# Altium schematic sheet S7CAS-PLEIADES-CARTE-MERE_P07_FPGA_2.SchDoc(S7CAS-PLEIADES-CARTE-MERE_P07_FPGA_2)
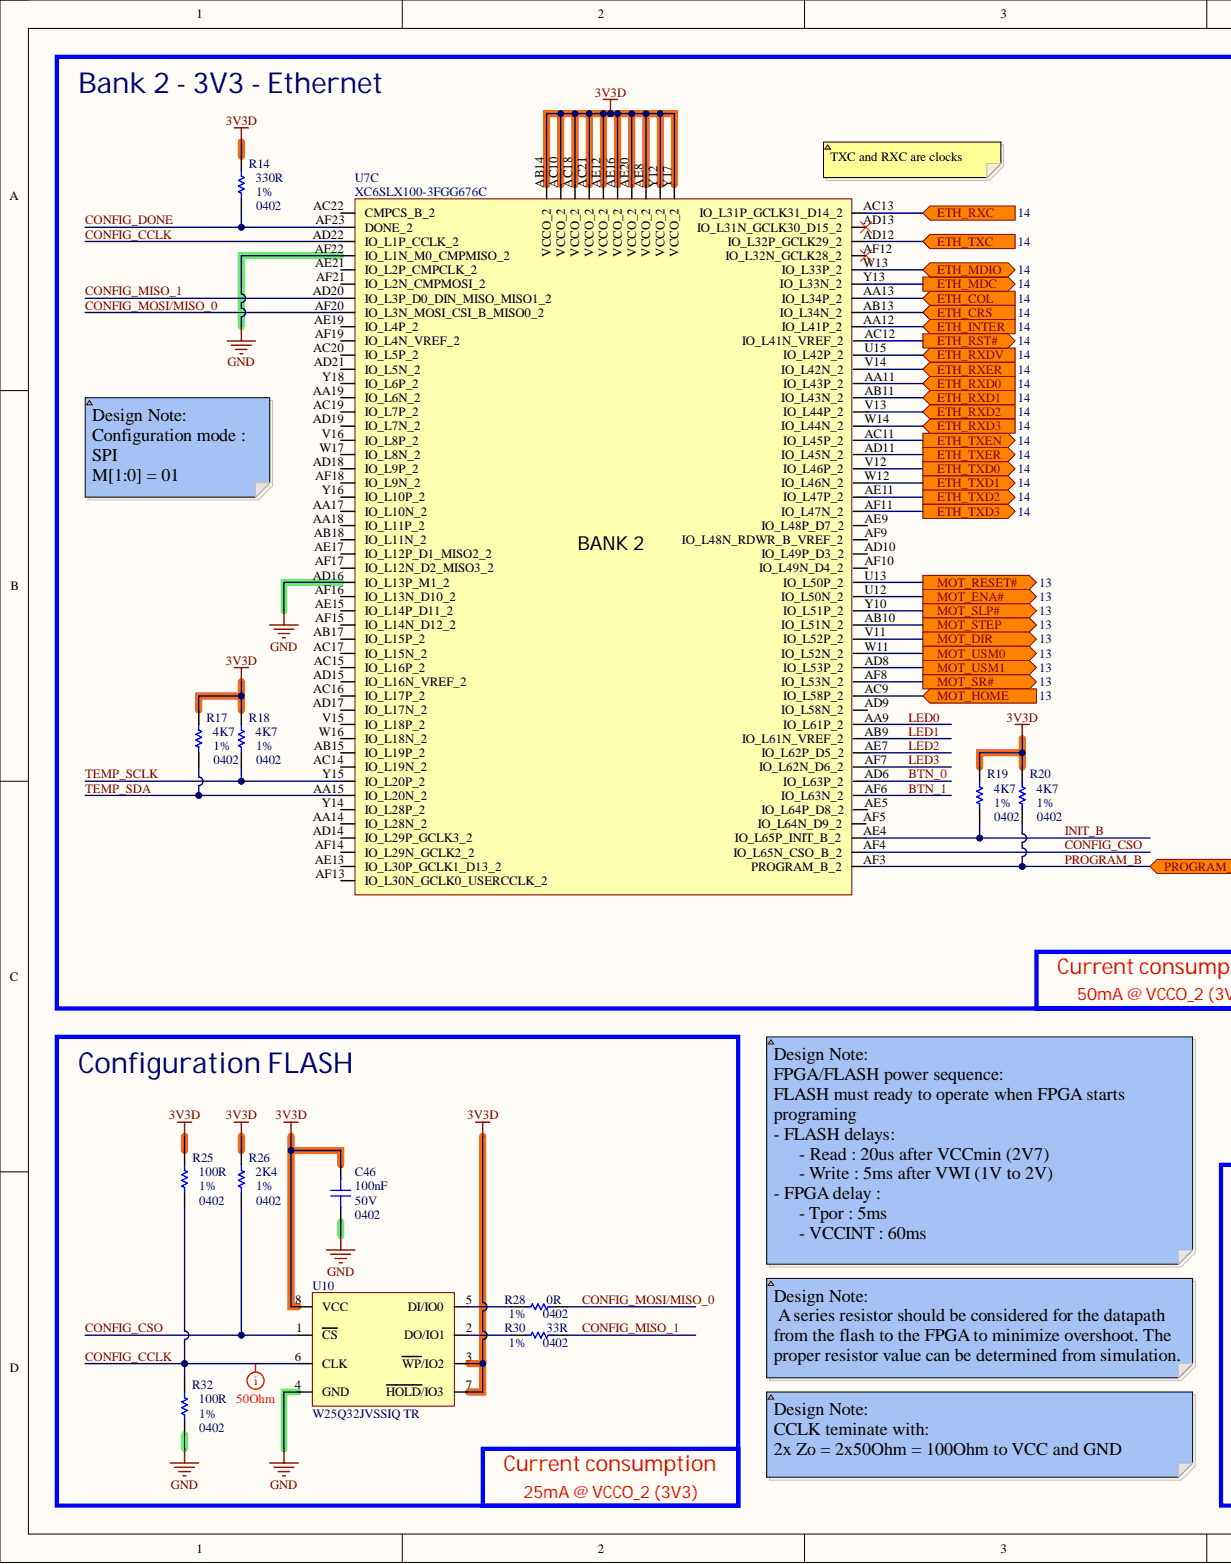
[diagram p0: S7CAS-PLEIADES-CARTE-MERE_P07_FPGA_2.SchDoc, left]
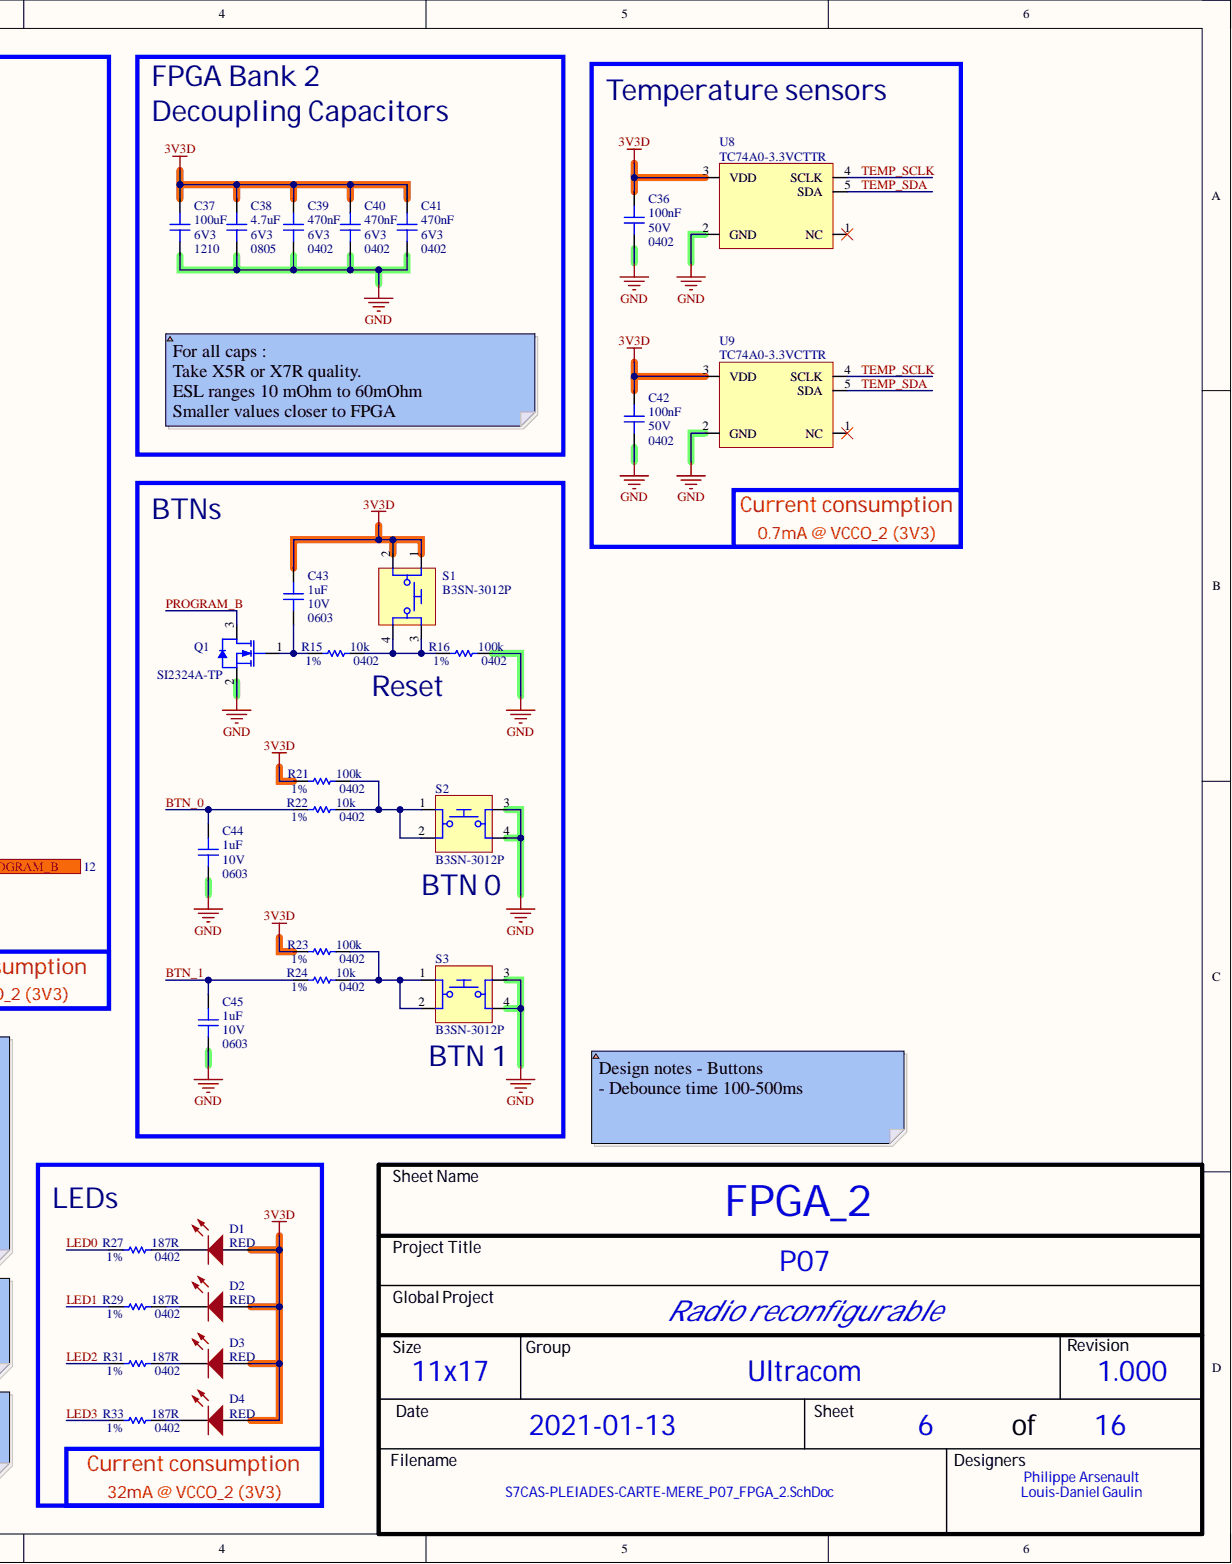
[diagram p1: S7CAS-PLEIADES-CARTE-MERE_P07_FPGA_2.SchDoc, right]

## Parts
C36  value 100nF  Package: 0402  Voltage: 50V  [CAP_0402]  (hidden comment: CAP - 0402)
C37  value 100uF  Package: 1210  Voltage: 6V3  [CAP_1210]  (hidden comment: CAP - 1210)
C38  value 4.7uF  Package: 0805  Voltage: 6V3  [CAP_0805]  (hidden comment: CAP - 0805)
C39  value 470nF  Package: 0402  Voltage: 6V3  [CAP_0402]  (hidden comment: CAP - 0402)
C40  value 470nF  Package: 0402  Voltage: 6V3  [CAP_0402]  (hidden comment: CAP - 0402)
C41  value 470nF  Package: 0402  Voltage: 6V3  [CAP_0402]  (hidden comment: CAP - 0402)
C42  value 100nF  Package: 0402  Voltage: 50V  [CAP_0402]  (hidden comment: CAP - 0402)
C43  value 1uF  Package: 0603  Voltage: 10V  [CAP_0603]  (hidden comment: CAP - 0603)
C44  value 1uF  Package: 0603  Voltage: 10V  [CAP_0603]  (hidden comment: CAP - 0603)
C45  value 1uF  Package: 0603  Voltage: 10V  [CAP_0603]  (hidden comment: CAP - 0603)
C46  value 100nF  Package: 0402  Voltage: 50V  [CAP_0402]  (hidden comment: CAP - 0402)
D1  value RED  [LED_0603]  (hidden comment: SML-311UTT86)
D2  value RED  [LED_0603]  (hidden comment: SML-311UTT86)
D3  value RED  [LED_0603]  (hidden comment: SML-311UTT86)
D4  value RED  [LED_0603]  (hidden comment: SML-311UTT86)
Q1  SI2324A-TP  [TRX_NMOS_SI2310-TP]
R14  value 330R  Package: 0402  Precision: 1%  [RES_0402]  (hidden comment: RES - 0402)
R15  value 10k  Package: 0402  Precision: 1%  [RES_0402]  (hidden comment: RES - 0402)
R16  value 100k  Package: 0402  Precision: 1%  [RES_0402]  (hidden comment: RES - 0402)
R17  value 4K7  [RES_0402]  (hidden comment: RES - 0402)
R18  value 4K7  Package: 0402  Precision: 1%  [RES_0402]  (hidden comment: RES - 0402)
R19  value 4K7  [RES_0402]  (hidden comment: RES - 0402)
R20  value 4K7  Package: 0402  Precision: 1%  [RES_0402]  (hidden comment: RES - 0402)
R21  value 100k  Package: 0402  Precision: 1%  [RES_0402]  (hidden comment: RES - 0402)
R22  value 10k  Package: 0402  Precision: 1%  [RES_0402]  (hidden comment: RES - 0402)
R23  value 100k  Package: 0402  Precision: 1%  [RES_0402]  (hidden comment: RES - 0402)
R24  value 10k  Package: 0402  Precision: 1%  [RES_0402]  (hidden comment: RES - 0402)
R25  value 100R  Package: 0402  Precision: 1%  [RES_0402]  (hidden comment: RES - 0402)
R26  value 2K4  Package: 0402  Precision: 1%  [RES_0402]  (hidden comment: RES - 0402)
R27  value 187R  Package: 0402  Precision: 1%  [RES_0402]  (hidden comment: RES - 0402)
R28  value 0R  Package: 0402  Precision: 1%  [RES_0402]  (hidden comment: RES - 0402)
R29  value 187R  Package: 0402  Precision: 1%  [RES_0402]  (hidden comment: RES - 0402)
R30  value 33R  Package: 0402  Precision: 1%  [RES_0402]  (hidden comment: RES - 0402)
R31  value 187R  Package: 0402  Precision: 1%  [RES_0402]  (hidden comment: RES - 0402)
R32  value 100R  Package: 0402  Precision: 1%  [RES_0402]  (hidden comment: RES - 0402)
R33  value 187R  Package: 0402  Precision: 1%  [RES_0402]  (hidden comment: RES - 0402)
S1  B3SN-3012P  [SWI_TACT_B3SN-3012P]
S2  B3SN-3012P  [SWI_TACT_B3SN-3012P]
S3  B3SN-3012P  [SWI_TACT_B3SN-3012P]
U7  XC6SLX100-3FGG676C  [FPGA_XILINX_FGG676]  (part C)
U8  TC74A0-3.3VCTTR  [SEN_TEMP_TC74A0-3.3VCTTR]
U9  TC74A0-3.3VCTTR  [SEN_TEMP_TC74A0-3.3VCTTR]
U10  W25Q32JVSSIQ TR  [MEM_FLASH_W25Q32JVSSIQ TR]

## Ports
ETH_COL, ETH_CRS, ETH_INTER, ETH_MDC, ETH_MDIO, ETH_RST#, ETH_RXC, ETH_RXD0, ETH_RXD1, ETH_RXD2, ETH_RXD3, ETH_RXDV, ETH_RXER, ETH_TXC, ETH_TXD0, ETH_TXD1, ETH_TXD2, ETH_TXD3, ETH_TXEN, ETH_TXER, MOT_DIR, MOT_ENA#, MOT_HOME, MOT_RESET#, MOT_SLP#, MOT_SR#, MOT_STEP, MOT_USM0, MOT_USM1, PROGRAM_B

## Nets
3V3D: C36.2 C37.2 C38.2 C39.2 C40.2 C41.2 C42.2 C43.2 C46.2 D1.A D2.A D3.A D4.A R14.2 R17.2 R18.2 R19.2 R20.2 R21.1 R23.1 R25.2 R26.2 S1.1 S1.2 U7.AB14(VCCO_2) U7.AC10(VCCO_2) U7.AC18(VCCO_2) U7.AC21(VCCO_2) U7.AE8(VCCO_2) U7.AE12(VCCO_2) U7.AE16(VCCO_2) U7.AE20(VCCO_2) U7.Y12(VCCO_2) U7.Y17(VCCO_2) U8.3(VDD) U9.3(VDD) U10.3(WP/IO2) U10.7(HOLD/IO3) U10.8(VCC)
GND: C36.1 C37.1 C38.1 C39.1 C40.1 C41.1 C42.1 C44.1 C45.1 C46.1 Q1.2 R16.2 R32.1 S2.3 S2.4 S3.3 S3.4 U7.AD16(IO_L13P_M1_2) U7.AF22(IO_L1N_M0_CMPMISO_2) U8.2(GND) U9.2(GND) U10.4(GND)
BTN_0: C44.2 R22.1 U7.AD6(IO_L63P_2)
BTN_1: C45.2 R24.1 U7.AF6(IO_L63N_2)
CONFIG_CCLK: R25.1 R32.2 U7.AD22(IO_L1P_CCLK_2) U10.6(CLK)
CONFIG_CSO: R26.1 U7.AF4(IO_L65N_CSO_B_2) U10.1(CS)
CONFIG_DONE: R14.1 U7.AF23(DONE_2)
CONFIG_MISO_1: R30.1 U7.AD20(IO_L3P_D0_DIN_MISO_MISO1_2)
CONFIG_MOSI/MISO_0: R28.1 U7.AF20(IO_L3N_MOSI_CSI_B_MISO0_2)
ETH_COL: U7.AA13(IO_L34P_2) ; port ETH_COL
ETH_CRS: U7.AB13(IO_L34N_2) ; port ETH_CRS
ETH_INTER: U7.AA12(IO_L41P_2) ; port ETH_INTER
ETH_MDC: U7.Y13(IO_L33N_2) ; port ETH_MDC
ETH_MDIO: U7.W13(IO_L33P_2) ; port ETH_MDIO
ETH_RST#: U7.AC12(IO_L41N_VREF_2) ; port ETH_RST#
ETH_RXC: U7.AC13(IO_L31P_GCLK31_D14_2) ; port ETH_RXC
ETH_RXD0: U7.AA11(IO_L43P_2) ; port ETH_RXD0
ETH_RXD1: U7.AB11(IO_L43N_2) ; port ETH_RXD1
ETH_RXD2: U7.V13(IO_L44P_2) ; port ETH_RXD2
ETH_RXD3: U7.W14(IO_L44N_2) ; port ETH_RXD3
ETH_RXDV: U7.U15(IO_L42P_2) ; port ETH_RXDV
ETH_RXER: U7.V14(IO_L42N_2) ; port ETH_RXER
ETH_TXC: U7.AD12(IO_L32P_GCLK29_2) ; port ETH_TXC
ETH_TXD0: U7.V12(IO_L46P_2) ; port ETH_TXD0
ETH_TXD1: U7.W12(IO_L46N_2) ; port ETH_TXD1
ETH_TXD2: U7.AE11(IO_L47P_2) ; port ETH_TXD2
ETH_TXD3: U7.AF11(IO_L47N_2) ; port ETH_TXD3
ETH_TXEN: U7.AC11(IO_L45P_2) ; port ETH_TXEN
ETH_TXER: U7.AD11(IO_L45N_2) ; port ETH_TXER
INIT_B: R19.1 U7.AE4(IO_L65P_INIT_B_2)
LED0: R27.1 U7.AA9(IO_L61P_2)
LED1: R29.1 U7.AB9(IO_L61N_VREF_2)
LED2: R31.1 U7.AE7(IO_L62P_D5_2)
LED3: R33.1 U7.AF7(IO_L62N_D6_2)
MOT_DIR: U7.V11(IO_L52P_2) ; port MOT_DIR
MOT_ENA#: U7.U12(IO_L50N_2) ; port MOT_ENA#
MOT_HOME: U7.AC9(IO_L58P_2) ; port MOT_HOME
MOT_RESET#: U7.U13(IO_L50P_2) ; port MOT_RESET#
MOT_SLP#: U7.Y10(IO_L51P_2) ; port MOT_SLP#
MOT_SR#: U7.AF8(IO_L53N_2) ; port MOT_SR#
MOT_STEP: U7.AB10(IO_L51N_2) ; port MOT_STEP
MOT_USM0: U7.W11(IO_L52N_2) ; port MOT_USM0
MOT_USM1: U7.AD8(IO_L53P_2) ; port MOT_USM1
PROGRAM_B: Q1.3 R20.1 U7.AF3(PROGRAM_B_2) ; port PROGRAM_B
TEMP_SCLK: R18.1 U7.Y15(IO_L20P_2) U8.4(SCLK) U9.4(SCLK)
TEMP_SDA: R17.1 U7.AA15(IO_L20N_2) U8.5(SDA) U9.5(SDA)
NetC43_1: C43.1 Q1.1 R15.1
NetD1_K: D1.K R27.2
NetD2_K: D2.K R29.2
NetD3_K: D3.K R31.2
NetD4_K: D4.K R33.2
NetR15_2: R15.2 R16.1 S1.3 S1.4
NetR21_2: R21.2 R22.2 S2.1 S2.2
NetR23_2: R23.2 R24.2 S3.1 S3.2
NetR28_2: R28.2 U10.5(DI/IO0)
NetR30_2: R30.2 U10.2(DO/IO1)

Not connected: U7 AA14(IO_L28N_2) AA17(IO_L10N_2) AA18(IO_L11P_2) AA19(IO_L6N_2) AB15(IO_L19P_2) AB17(IO_L15P_2) AB18(IO_L11N_2) AC14(IO_L19N_2) AC15(IO_L16P_2) AC16(IO_L17P_2) AC17(IO_L15N_2) AC19(IO_L7P_2) AC20(IO_L5P_2) AC22(CMPCS_B_2) AD9(IO_L58N_2) AD10(IO_L49P_D3_2) AD13(IO_L31N_GCLK30_D15_2) AD14(IO_L29P_GCLK3_2) AD15(IO_L16N_VREF_2) AD17(IO_L17N_2) AD18(IO_L9P_2) AD19(IO_L7N_2) AD21(IO_L5N_2) AE5(IO_L64P_D8_2) AE9(IO_L48P_D7_2) AE13(IO_L30P_GCLK1_D13_2) AE15(IO_L14P_D11_2) AE17(IO_L12P_D1_MISO2_2) AE19(IO_L4P_2) AE21(IO_L2P_CMPCLK_2) AF5(IO_L64N_D9_2) AF9(IO_L48N_RDWR_B_VREF_2) AF10(IO_L49N_D4_2) AF12(IO_L32N_GCLK28_2) AF13(IO_L30N_GCLK0_USERCCLK_2) AF14(IO_L29N_GCLK2_2) AF15(IO_L14N_D12_2) AF16(IO_L13N_D10_2) AF17(IO_L12N_D2_MISO3_2) AF18(IO_L9N_2) AF19(IO_L4N_VREF_2) AF21(IO_L2N_CMPMOSI_2) V15(IO_L18P_2) V16(IO_L8P_2) W16(IO_L18N_2) W17(IO_L8N_2) Y14(IO_L28P_2) Y16(IO_L10P_2) Y18(IO_L6P_2); U8 1(NC); U9 1(NC)

## Library data of the parts
C36 C39-C42 C46 = CAP - 0402: Precision: 10%; Component Kind: Standard; Description: CAP - 0402; Library Name: S7CAS_LIB2021.IntLib; CreatedBy: Jonathan Bouchard
C37 = CAP - 1210: Precision: 10%; Component Kind: Standard; Description: CAP - 1210; Library Name: S7CAS_LIB2021.IntLib; CreatedBy: Jonathan Bouchard
C38 = CAP - 0805: Precision: 10%; Component Kind: Standard; Description: CAP - 0805; Library Name: S7CAS_LIB2021.IntLib; CreatedBy: Jonathan Bouchard
C43-C45 = CAP - 0603: Precision: 10%; Component Kind: Standard; Description: CAP - 0603; Library Name: S7CAS_LIB2021.IntLib; CreatedBy: Jonathan Bouchard
D1-D4 = LED_SML-311UTT86: LED - 620nM RED 2mA - 0603; Mfg: ROHM Semiconductor; Power: 44mW; Voltage: 1.8V; Component Kind: Standard; Current: 2mA; HelpURL: http://media.digikey.com/pdf/Data%20Sheets/Rohm%20PDFs/SML-311%20Series.pdf; Library Name: S7CAS_LIB2021.IntLib; Mfg Part Number: SML-311UTT86; Package: 0603; Supplier 1: Digikey; Supplier Part Number 1: 511-1304-1-ND; Temperature: -30°C to 85°C; CreatedBy: Jonathan Bouchard
Q1 = TRX_NMOS_SI2324A-TP: TRX - MOSFET N-CH 100V 2A - SOT-23; Manufacturer #1: Micro Commercial Co; Magnetic Material: UNKNOWN; Polarized: Yes; Power Max: 350mW; Voltage rating: 100V (Vds max), 2V (Vgs @ 250uA); Assembly Type: SMD; Component Kind: Standard; Current Rating: 2A; HelpURL: https://www.mccsemi.com/pdf/Products/SI2324A(SOT-23)-A.pdf; Library Name: S7CAS_P07.IntLib; Package: SOT-23; Part Number #1: SI2324A-TP; Supplier 1: Digi-Key; Supplier Part Number 1: SI2324A-TPMSCT-ND; Temperature: -55°C ~ 150°C (TJ)
R14-R16 R18 R20-R33 = RES - 0402: Power: 1/16W; Voltage: 50V; Component Kind: Standard; Description: RES - 0402; Library Name: S7CAS_LIB2021.IntLib; CreatedBy: Jonathan Bouchard
R17 R19 = RES - 0402: Power: 1/16W; Precision: 1%; Voltage: 50V; Component Kind: Standard; Description: RES - 0402; Library Name: S7CAS_LIB2021.IntLib; Package: 0402; CreatedBy: Jonathan Bouchard
S1-S3 = SWI_TACT_B3SN-3012P_1: SWI - SWITCH TACTILE SPST-NO 0.05A 24V -SMD; Manufacturer #1: Omron Electronics Inc-EMC Div; Cryo: UNKNOWN; Current Rating: 50mA; GRAMS Lib Spec: 2.8; Polarized: No; Voltage rating: 24VDC; Assembly Type: SMD; Component Kind: Standard; HelpURL: http://omronfs.omron.com/en_US/ecb/products/pdf/en-b3sn.pdf; Library Name: S7CAS_LIB2021.IntLib; Magnetic Material: UNKNOWN; Package: SMD; Part Number #1: B3SN-3012P; Supplier 1: Digi-Key; Supplier Part Number 1: SW261CT-ND; Temperature: -25°C ~ 70°C; Value: SPST-NO; CreatedBy/Date: Olivier Bernard / 5/12/2017; VerifiedBy: Caroline Paulin / 11 juillet 2018
U7 = FPGA_XC6SLX100-3FGG676C: FPGA - XILINX SPARTAN 6 480 I/O - BGA-676; Voltage: 1.14 V ~ 1.26 V; Component Kind: Standard; HelpURL: https://www.xilinx.com/support/documentation/data_sheets/ds162.pdf; Library Name: S7CAS_LIB2021.IntLib; Mfg: Xilinx; Mfg Part Number: XC6SLX100-3FGG676C; Package: BGA-676; Supplier 1: Digikey; Supplier Part Number 1: 122-1760-ND; Temperature: 0°C ~ 85°C (TJ); CreatedBy: Jonathan Bouchard
U8 U9 = SENS_TEMP_TC74A0-3.3VCTTR: SENS - TEMPERATURE SENSOR I2C/SMBUS - SOT23-5; Mfg: Microchip; Precision: ±2°C (±3°C); Voltage: 2.7 V ~ 5.5 V; Component Kind: Standard; HelpURL: http://ww1.microchip.com/downloads/en/DeviceDoc/21462D.pdf; Library Name: S7CAS_LIB2021.IntLib; Mfg Part Number: TC74A0-3.3VCTTR; Package: SOT23-5; Supplier 1: Digikey; Supplier Part Number 1: TC74A0-3.3VCTDKR-ND; Temperature: -40°C ~ 125°C; CreatedBy: Jonathan Bouchard
U10 = MEM_FLASH_W25Q32JVSSIQ TR: MEM - FLASH NOR 32Mb 133MHZ - 8-SOIC; Mfg: Winbond Electronics; Voltage: 2.7 V ~ 3.6 V; Component Kind: Standard; HelpURL: https://www.winbond.com/resource-files/w25q32jv%20revg%2003272018%20plus.pdf; Library Name: S7CAS_P07.IntLib; Mfg Part Number: W25Q32JVSSIQ TR; Package: 8-SOIC; Supplier 1: Digikey; Supplier Part Number 1: W25Q32JVSSIQCT-ND; Temperature: -40°C ~ 85°C (TA); CreatedBy: Louis-Daniel Gaulin
